# Altium schematic sheet DC-DC Isolationn.SchDoc("DC-DC Isolationn")
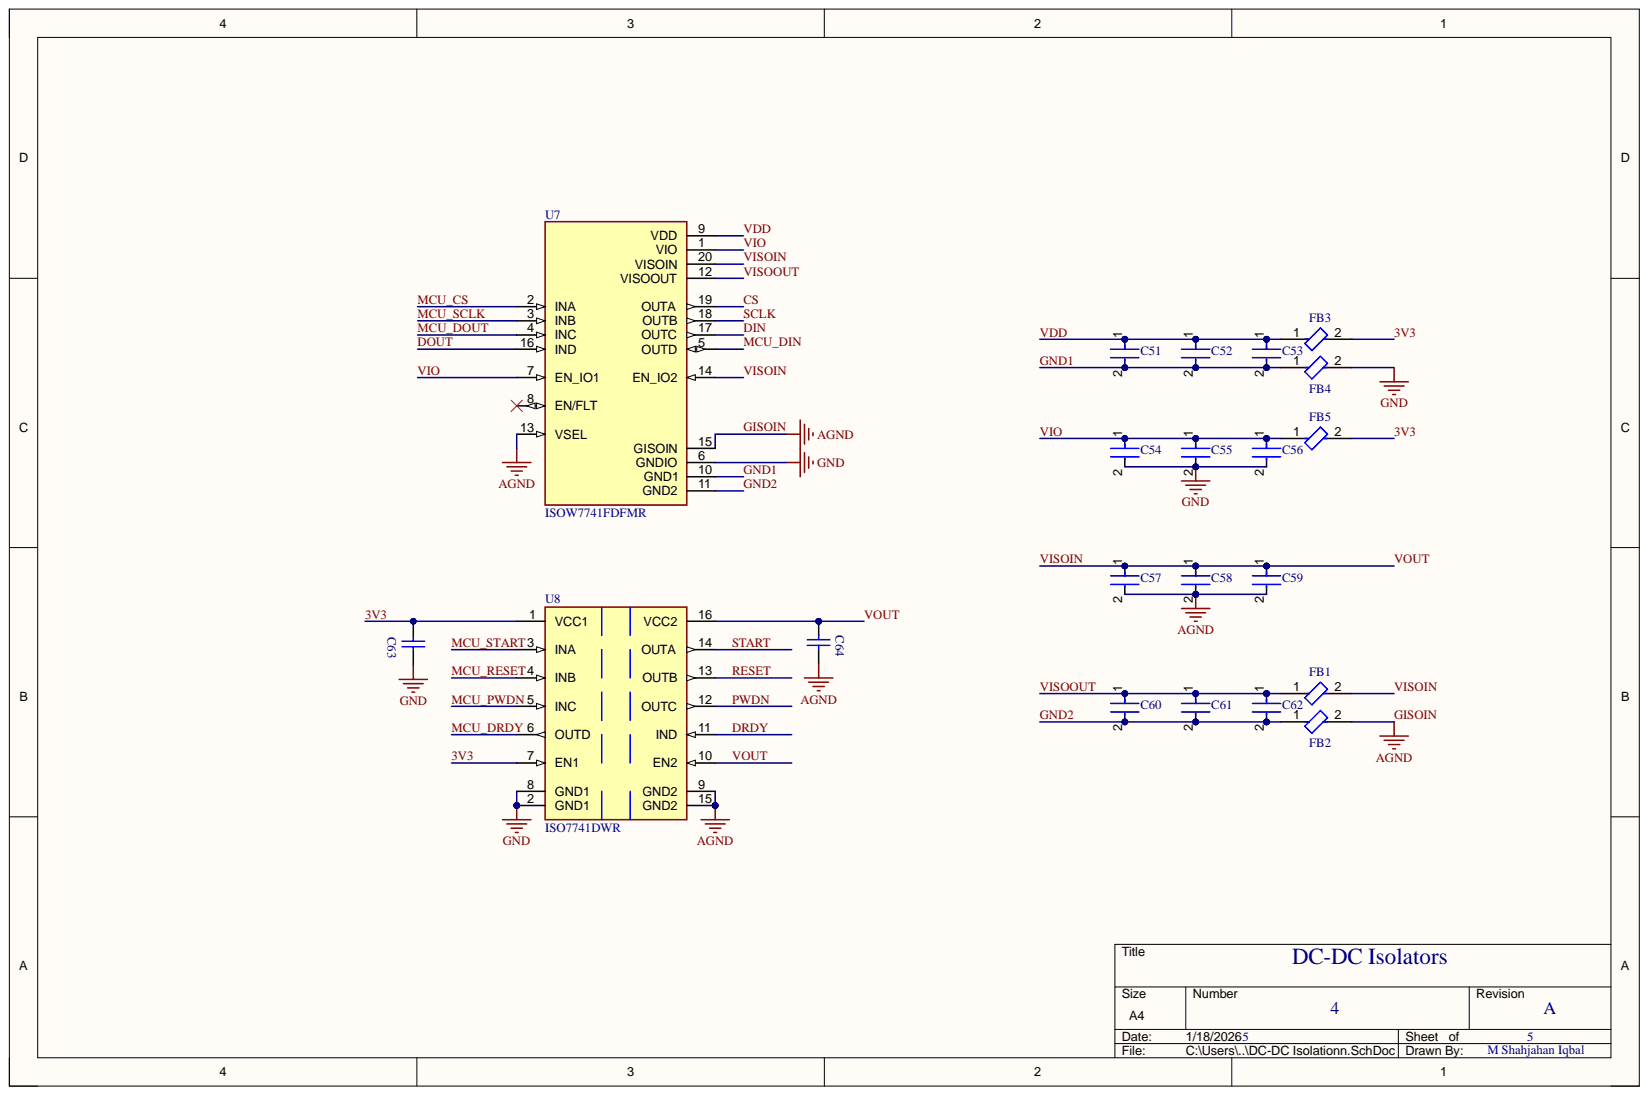
[diagram p0: DC-DC Isolationn.SchDoc]

## Parts
C51  C0603C102K8RACTU  [FP-C0603C-CF-MFG]  (value not drawn)
C52  CL10B105KP8NNNC  [FP-CL10-IPC_C]  (value not drawn)
C53  GRM188Z71A106KA73D  [FP-GRM188-0_2-IPC_C]  (value not drawn)
C54  C0603C102K8RACTU  [FP-C0603C-CF-MFG]  (value not drawn)
C55  CL10B105KP8NNNC  [FP-CL10-IPC_C]  (value not drawn)
C56  GRM188Z71A106KA73D  [FP-GRM188-0_2-IPC_C]  (value not drawn)
C57  C0603C102K8RACTU  [FP-C0603C-CF-MFG]  (value not drawn)
C58  CL10B105KP8NNNC  [FP-CL10-IPC_C]  (value not drawn)
C59  GRM188Z71A106KA73D  [FP-GRM188-0_2-IPC_C]  (value not drawn)
C60  C0603C102K8RACTU  [FP-C0603C-CF-MFG]  (value not drawn)
C61  CL10B105KP8NNNC  [FP-CL10-IPC_C]  (value not drawn)
C62  GRM188Z71A106KA73D  [FP-GRM188-0_2-IPC_C]  (value not drawn)
C63  885012206020  [WCAP-CSGP_0603_L1.6W0.8H0.8_Reflow]  (value not drawn)
C64  885012206020  [WCAP-CSGP_0603_L1.6W0.8H0.8_Reflow]  (value not drawn)
FB1  BLM15EX331SN1D  [FP-BLM15-0_05-IPC_B]  (value not drawn)
FB2  BLM15EX331SN1D  [FP-BLM15-0_05-IPC_B]  (value not drawn)
FB3  BLM15EX331SN1D  [FP-BLM15-0_05-IPC_B]  (value not drawn)
FB4  BLM15EX331SN1D  [FP-BLM15-0_05-IPC_B]  (value not drawn)
FB5  BLM15EX331SN1D  [FP-BLM15-0_05-IPC_B]  (value not drawn)
U7  ISOW7741FDFMR  [SOIC127P1030X355-20N]
U8  ISO7741DWR  [FP-DW0016B-MFG]

## Nets
GND: C54.2 C55.2 C56.2 C63.2 FB4.2 U7.6(GNDIO) U8.2(GND1) U8.8(GND1)
3V3: C63.1 FB3.2 FB5.2 U8.1(VCC1) U8.7(EN1)
CS: U7.19(OUTA)
DIN: U7.17(OUTC)
DOUT: U7.16(IND)
DRDY: U8.11(IND)
GISOIN: C57.2 C58.2 C59.2 C64.2 FB2.2 U7.13(VSEL) U7.15(GISOIN) U8.9(GND2) U8.15(GND2)
GND1: C51.2 C52.2 C53.2 FB4.1 U7.10(GND1)
GND2: C60.2 C61.2 C62.2 FB2.1 U7.11(GND2)
MCU_CS: U7.2(INA)
MCU_DIN: U7.5(OUTD)
MCU_DOUT: U7.4(INC)
MCU_DRDY: U8.6(OUTD)
MCU_PWDN: U8.5(INC)
MCU_RESET: U8.4(INB)
MCU_SCLK: U7.3(INB)
MCU_START: U8.3(INA)
PWDN: U8.12(OUTC)
RESET: U8.13(OUTB)
SCLK: U7.18(OUTB)
START: U8.14(OUTA)
VDD: C51.1 C52.1 C53.1 FB3.1 U7.9(VDD)
VIO: C54.1 C55.1 C56.1 FB5.1 U7.1(VIO) U7.7(EN_IO1)
VISOIN: C57.1 C58.1 C59.1 C64.1 FB1.2 U7.14(EN_IO2) U7.20(VISOIN) U8.10(EN2) U8.16(VCC2)
VISOOUT: C60.1 C61.1 C62.1 FB1.1 U7.12(VISOOUT)

Not connected: U7 8(EN/FLT)

## Library data of the parts
C51 C54 C57 C60 = CMP-2006-03024-2: CAP CER 1000PF 10V 10% X7R 0603; Manufacturer: KEMET; Automotive Grade: Grade 1; Capacitance: 1,000 pF; Material: Ceramic; Temperature Coefficient: 30ppm/°C; Tolerance: 0.1; Value: 1,000 pF; Automotive: Yes; Category: Cap; Component Kind: Standard; ComponentLink2Description: Datasheet; ComponentLink2URL: https://api.kemet.com/component-edge/download/datasheet/C0603C100J5GACAUTO.pdf; Device Class L1: Passive Components; Device Class L2: Capacitors; Device Class L3: Ceramic Capacitors; Digikey Description: CAP CER 1000PF 10V 10% X7R 0603; Height: 0.87mm; Lead Free: Yes; Library Name: Altium Content Vault; Manufacturer Part Number: C0603C102K8RACTU; Mouser Description: Multilayer Ceramic Capacitors MLCC - SMD/SMT 10volts 1000pF X7R 10%; Octopart: https://octopart.com/c0603c102k8ractu-kemet-9143718; Package: C0603C-CF; RoHS: TRUE; Temperature: -55°C to +125°C; Temperature Characteristic: X7R; Temperature Range High: +125°C; Temperature Range Low: -55°C; Voltage Rating: 10 V
C52 C55 C58 C61 = CMP-2000-06094-2: Cap Ceramic 1uF 10V X7R ±10% Pad SMD 0603 +125°C T/R; Capacitance: 1uF; Case Code (Imperial): 0603; Case Code (Metric): 1608; Case/Package: 0603; Component Kind: Standard; Contact Plating: Tin; Depth: 0.8mm; Dielectric: X7R; Height: 0.8mm; Lead Free: Lead Free; Length: 1.6mm; Library Name: Altium Content Vault; Max Operating Temperature: 125°C; Min Operating Temperature: -55°C; Mount: Surface Mount; Package Quantity: 1; Packaging: Tape and Reel; Radiation Hardening: No; Thickness: 0.035inch; Tolerance: 10%; Voltage Rating: 10V; Voltage Rating (DC): 10V; Width: 0.031inch
C53 C56 C59 C62 = CMP-06035-000049-2: Chip Multilayer Ceramic Capacitors for General Purpose, 0603, 10uF, X7R, 15%, 10%, 10V; Component Kind: Standard; Library Name: Altium Content Vault
C63 C64 = CMP-03913-53914729-2: Capacitor 100NF Multilayer Ceramic MLCC10 V , X7R Dielectric 0603 Surface Mount; Insulation Resistance: 5GΩ; Tolerance: 10%; Voltage Rating: 10V; Capacitance: 100nF; Case Code (Imperial): 0603; Case Code (Metric): 1608; Case/Package: 0603; Component Kind: Standard; Dielectric: X7R; Height: 800µm; Length: 1.6mm; Library Name: Altium Content Vault; Max Operating Temperature: 125°C; Min Operating Temperature: -55°C; Mount: Surface Mount; REACH SVHC: Unknown; RoHS: Compliant; Thickness: 863.6µm; Voltage Rating (DC): 10V; Width: 800µm
FB1-FB5 = CMP-06046-002536-1: Chip Ferrite Bead, 0402, 330Ω @ 100MHz, 0.205Ω, 25%, 1.1A; Component Kind: Standard; Library Name: Altium Content Vault; RoHS Compliant: Yes
U7 = ISOW7741FDFMR: Low-emissions, low-ripple, quad-channel, 3/1, reinforced digital isolator with integrated power; MF: Texas Instruments; Check_prices: https://www.snapeda.com/parts/ISOW7741FDFMR/Texas+Instruments/view-part/?ref=eda; Component Kind: Standard; Library Name: ISOW7741FDFMR.IntLib; MP: ISOW7741FDFMR; Package: None; SnapEDA_Link: https://www.snapeda.com/parts/ISOW7741FDFMR/Texas+Instruments/view-part/?ref=snap
U8 = CMP-04872-000013-1: DGTL ISO 5KV 4CH GEN PURP 16SOIC; Manufacturer: Texas Instruments; Max Junction Temp: +150°C; Max Supply Voltage: 5.5V; Min Supply Voltage: 2.25V; Nominal Supply Current: 1.5-18mA; Standoff Height: 0.1mm; Ambient Temperature Range High: +125°C; Ambient Temperature Range Low: -55°C; Automotive: No; Category: IC; Component Kind: Standard; ComponentLink2Description: Datasheet; ComponentLink2URL: http://www.ti.com/lit/ds/symlink/iso7741.pdf; Device Class L1: Integrated Circuits (ICs); Device Class L2: Interface ICs; Device Class L3: unset; Digikey Description: DGTL ISO 5KV 4CH GEN PURP 16SOIC; Height: 2.65mm; Ipc Land Pattern Name: SOIC127P1030X265-16; Lead Free: Yes; Library Name: Altium Content Vault; Manufacturer Part Number: ISO7741DWR; Mouser Description: High-speed, low-power, robust EMC quad-channel digital isolator 16-SOIC -55 to 125; Octopart: https://octopart.com/iso7741dwr-texas+instruments-71651905; Package: DW0016B; RoHS: TRUE; Temperature: -55°C to +125°C; Temperature Range High: +125°C; Temperature Range Low: -55°C
